# Altium schematic sheet blip_sheet2.SchDoc
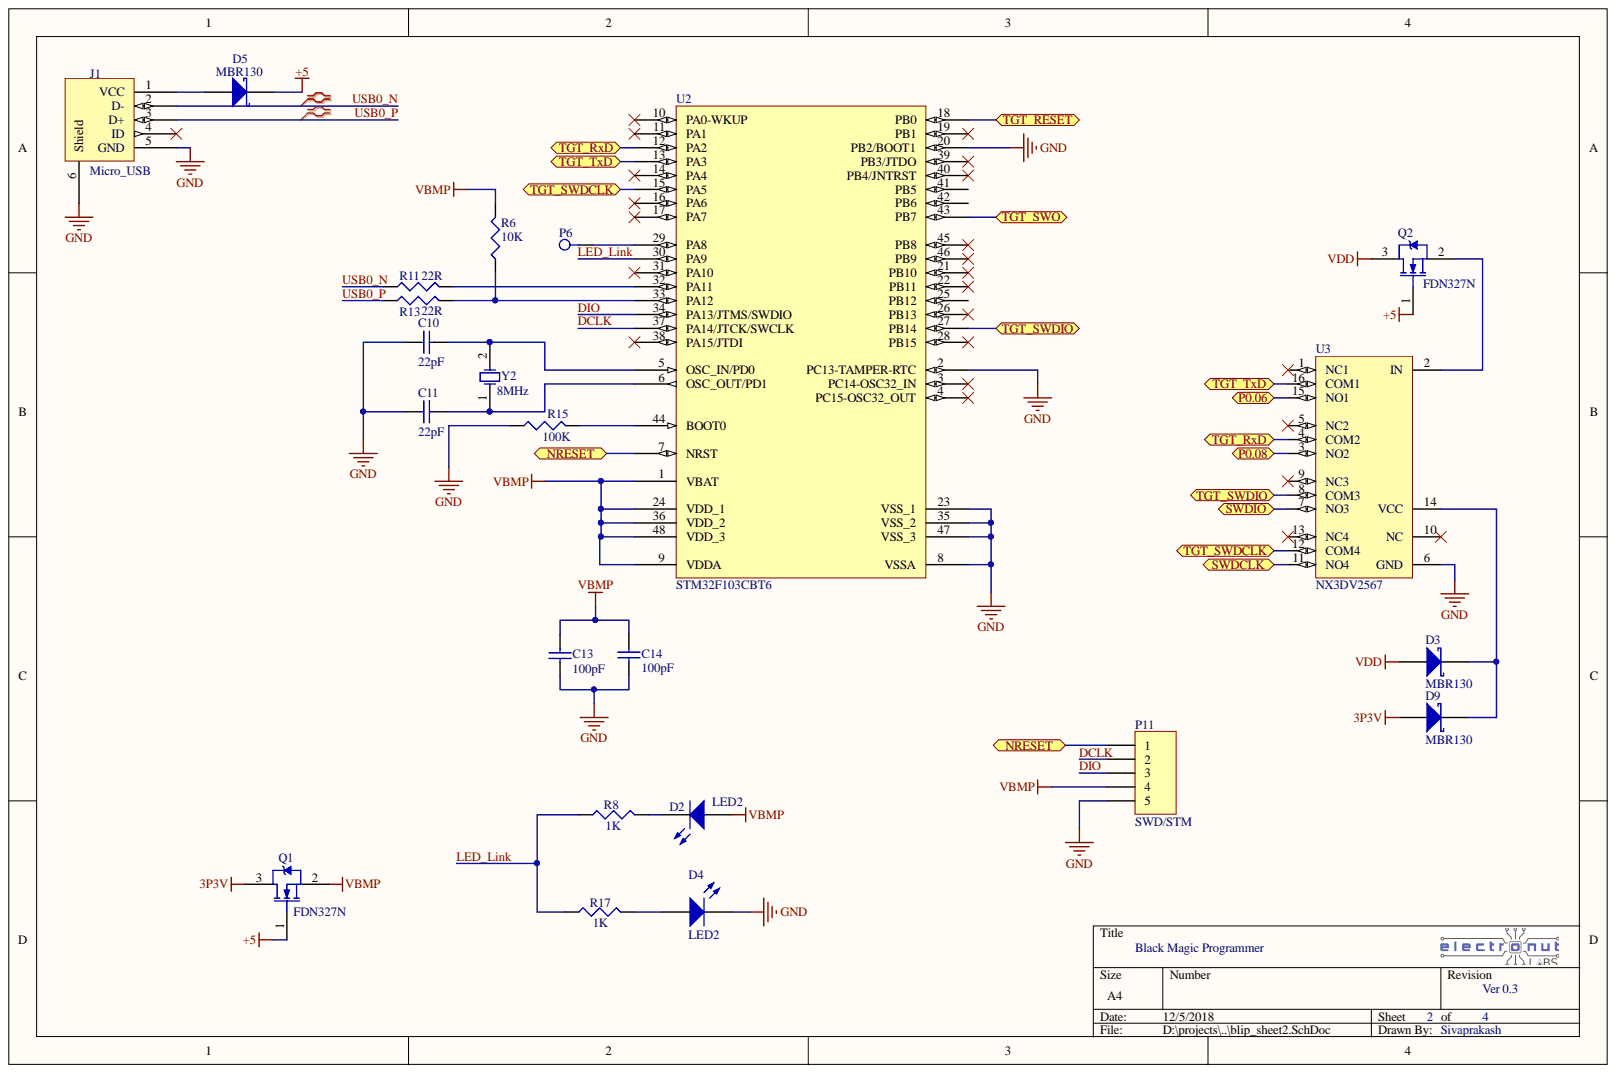
[diagram p0: blip_sheet2.SchDoc]

## Parts
C10  22pF  [1608[0603]]
C11  22pF  [1608[0603]]
C13  100pF  [1608[0603]]
C14  100pF  [1608[0603]]
D2  LED2  [1608[0603]]
D3  MBR130  [SOD_123]
D4  LED2  [1608[0603]]
D5  MBR130  [SOD_123]
D9  MBR130  [SOD_123]
J1  Micro_USB  [Micro_USB]
P6  MCO  [TestPoint]  (value not drawn)
P11  SWD/STM  [1x05_pin_1.27mm_pitch]
Q1  FDN327N  [SOT-23_N]
Q2  FDN327N  [SOT-23_N]
R6  10K  [J1-0603]
R8  1K  [J1-0603]
R11  22R  [J1-0603]
R13  22R  [J1-0603]
R15  100K  [J1-0603]
R17  1K  [J1-0603]
U2  STM32F103CBT6  [48_LQFPN]
U3  NX3DV2567  [NX3DV2567]
Y2  8MHz  [8MHz_crystal]

## Ports
NRESET, P0.06, P0.08, SWDCLK, SWDIO, TGT_RESET, TGT_RxD, TGT_SWDCLK, TGT_SWDIO, TGT_SWO, TGT_TxD

## Nets
3P3V: D9.1 Q1.3
+5: D5.2 Q1.1 Q2.1
GND: C10.1 C11.1 C13.1 C14.1 D4.2 J1.5(GND) J1.6(Shield) P11.5 R15.1 U2.2(PC13-TAMPER-RTC) U2.8(VSSA) U2.20(PB2/BOOT1) U2.23(VSS_1) U2.35(VSS_2) U2.47(VSS_3) U3.6(GND)
VBMP: C13.2 C14.2 D2.1 P11.4 Q1.2 R6.2 U2.1(VBAT) U2.9(VDDA) U2.24(VDD_1) U2.36(VDD_2) U2.48(VDD_3)
VDD: D3.1 Q2.3
DCLK: P11.2 U2.37(PA14/JTCK/SWCLK)
DIO: P11.3 U2.34(PA13/JTMS/SWDIO)
LED_Link: R8.1 R17.1 U2.30(PA9)
NRESET: P11.1 U2.7(NRST) ; port NRESET
P0.06: U3.15(NO1) ; port P0.06
P0.08: U3.3(NO2) ; port P0.08
SWDCLK: U3.11(NO4) ; port SWDCLK
SWDIO: U3.7(NO3) ; port SWDIO
TGT_RESET: U2.18(PB0) ; port TGT_RESET
TGT_RxD: U2.12(PA2) U3.4(COM2) ; port TGT_RxD
TGT_SWDCLK: U2.15(PA5) U3.12(COM4) ; port TGT_SWDCLK
TGT_SWDIO: U2.27(PB14) U3.8(COM3) ; port TGT_SWDIO
TGT_SWO: U2.43(PB7) ; port TGT_SWO
TGT_TxD: U2.13(PA3) U3.16(COM1) ; port TGT_TxD
USB0_N: J1.2(D-) R11.1
USB0_P: J1.3(D+) R13.1
NetC10_2: C10.2 U2.5(OSC_IN/PD0) Y2.2
NetC11_2: C11.2 U2.6(OSC_OUT/PD1) Y2.1
NetD2_2: D2.2 R8.2
NetD3_2: D3.2 D9.2 U3.14(VCC)
NetD4_1: D4.1 R17.2
NetD5_1: D5.1 J1.1(VCC)
NetP6_1: P6.1 U2.29(PA8)
NetQ2_2: Q2.2 U3.2(IN)
NetR6_1: R6.1 R13.2 U2.33(PA12)
NetR11_2: R11.2 U2.32(PA11)
NetR15_2: R15.2 U2.44(BOOT0)

Not connected: J1 4(ID); U2 3(PC14-OSC32_IN) 4(PC15-OSC32_OUT) 10(PA0-WKUP) 11(PA1) 14(PA4) 16(PA6) 17(PA7) 19(PB1) 21(PB10) 22(PB11) 25(PB12) 26(PB13) 28(PB15) 31(PA10) 38(PA15/JTDI) 39(PB3/JTDO) 40(PB4/JNTRST) 41(PB5) 42(PB6) 45(PB8) 46(PB9); U3 1(NC1) 5(NC2) 9(NC3) 10(NC) 13(NC4)

## Library data of the parts
C10 C11 C13 C14 = Cap Semi: Capacitor (Semiconductor SIM Model); Component Kind: Standard; LatestRevisionDate: 29-May-2009; LatestRevisionNote: IPC-7351 Footprint Added.; Library Name: Miscellaneous Devices.IntLib; PackageDescription: Chip Capacitor; PackageReference: C1206; Published: 8-Jun-2000; Signal Integrity: Cap Semi; Simulation: CAP; Publisher: Altium Limited
D2 D4 = LED2: Typical RED, GREEN, YELLOW, AMBER GaAs LED; Component Kind: Standard; LatestRevisionDate: 17-Jul-2002; LatestRevisionNote: Re-released for DXP Platform.; Library Name: Miscellaneous Devices.IntLib; PackageDescription: SM LED; 2 Flat Leads; PackageReference: 3.2X1.6X1.1; PackageVersion: Feb-2002; Published: 8-Jun-2000; Simulation: LED2; Publisher: Altium Limited
D3 D5 D9 = D Schottky: Schottky Diode; Code_JEDEC: DO-214-AA; Component Kind: Standard; LatestRevisionDate: 17-Jul-2002; LatestRevisionNote: Re-released for DXP Platform.; Library Name: Miscellaneous Devices.IntLib; PackageDescription: DO-214-AA/SMB; 2 C-Bend Leads; Body 5.3 x 3.6 mm, inc. leads (LxW); PackageReference: SMB; Published: 8-Jun-2000; Signal Integrity: D Schottky; Simulation: SKYDIODE; Publisher: Altium Limited
J1 = Micro_USB: Micro USB 2.0; Component Kind: Standard; Library Name: blip.SchLib
P6 = Test_Point: Component Kind: Standard; Library Name: blip.SchLib
P11 = Header 5: Header, 5-Pin; Component Kind: Standard; LatestRevisionDate: 17-Jul-2002; LatestRevisionNote: Re-released for DXP Platform.; Library Name: Miscellaneous Connectors.IntLib; Signal Integrity: Connector; Publisher: Altium Limited
Q1 Q2 = NMOS-2: N-Channel Power MOSFET; Note: Pin 2 is electrically connected to pin 4.; Component Kind: Standard; ComponentLink1Description: Manufacturer Link; ComponentLink1URL: http://www.irf.com/; DatasheetVersion: PD-9.814; LatestRevisionDate: 17-Jul-2002; LatestRevisionNote: Re-released for DXP Platform.; Library Name: Miscellaneous Devices.IntLib; PackageDescription: TO, Thru-Hole, Vertical, Heatsink Mounted; 3 In-Line Leads; Pitch 2.54 mm; PackageReference: TO-220AB; PackageVersion: Feb-1997; Published: 8-Jun-2000; Simulation: IRF1010; Publisher: Altium Limited
R6 R8 R11 R13 R15 R17 = Res3: Resistor; Component Kind: Standard; LatestRevisionDate: 29-May-2009; LatestRevisionNote: IPC-7351 Footprint Added.; Library Name: Miscellaneous Devices.IntLib; PackageDescription: Chip Resistor; PackageReference: J1-0603; Published: 8-Jun-2000; Signal Integrity: Res; Simulation: RESISTOR; Publisher: Altium Limited
U2 = STM32F103CBT6: STM32 ARM-based 32-bit MCU with 128 Kbytes Flash, 48-pin LQFP, Industrial Temperature; Component Kind: Standard; ComponentLink1Description: Manufacturer Link; ComponentLink1URL: www.st.com/stm32; ComponentLink2Description: Datasheet; ComponentLink2URL: http://www.st.com/stonline/products/literature/ds/13587.pdf; DatasheetVersion: rev6, Mar-2008; Library Name: ST Microcontroller 32-Bit STM32.IntLib; PackageDescription: 48-Pin Low-Profile Quad Flat Pack, 7 x 7 mm Body, 0.5 mm Pitch (LQFP48); PackageReference: LQFP48; PackageVersion: Mar-2008; Published: 30-May-2008; Publisher: Altium Limited
U3 = NX3DV2567: Low-ohmic four-pole double-throw analog switch; Component Kind: Standard; Library Name: blip.SchLib
Y2 = XTAL: Crystal Oscillator; Component Kind: Standard; LatestRevisionDate: 17-Jul-2002; LatestRevisionNote: Re-released for DXP Platform.; Library Name: Miscellaneous Devices.IntLib; PackageDescription: Crystal, Thru-Hole; 2 Leads; Body 3.1 x 8.2 mm (Dia.xH); PackageReference: R38; Published: 8-Jun-2000; Simulation: XTAL; Publisher: Altium Limited
